# Altium schematic sheet [3]-DCDC-4V2.SchDoc([3]-DCDC-4V2)
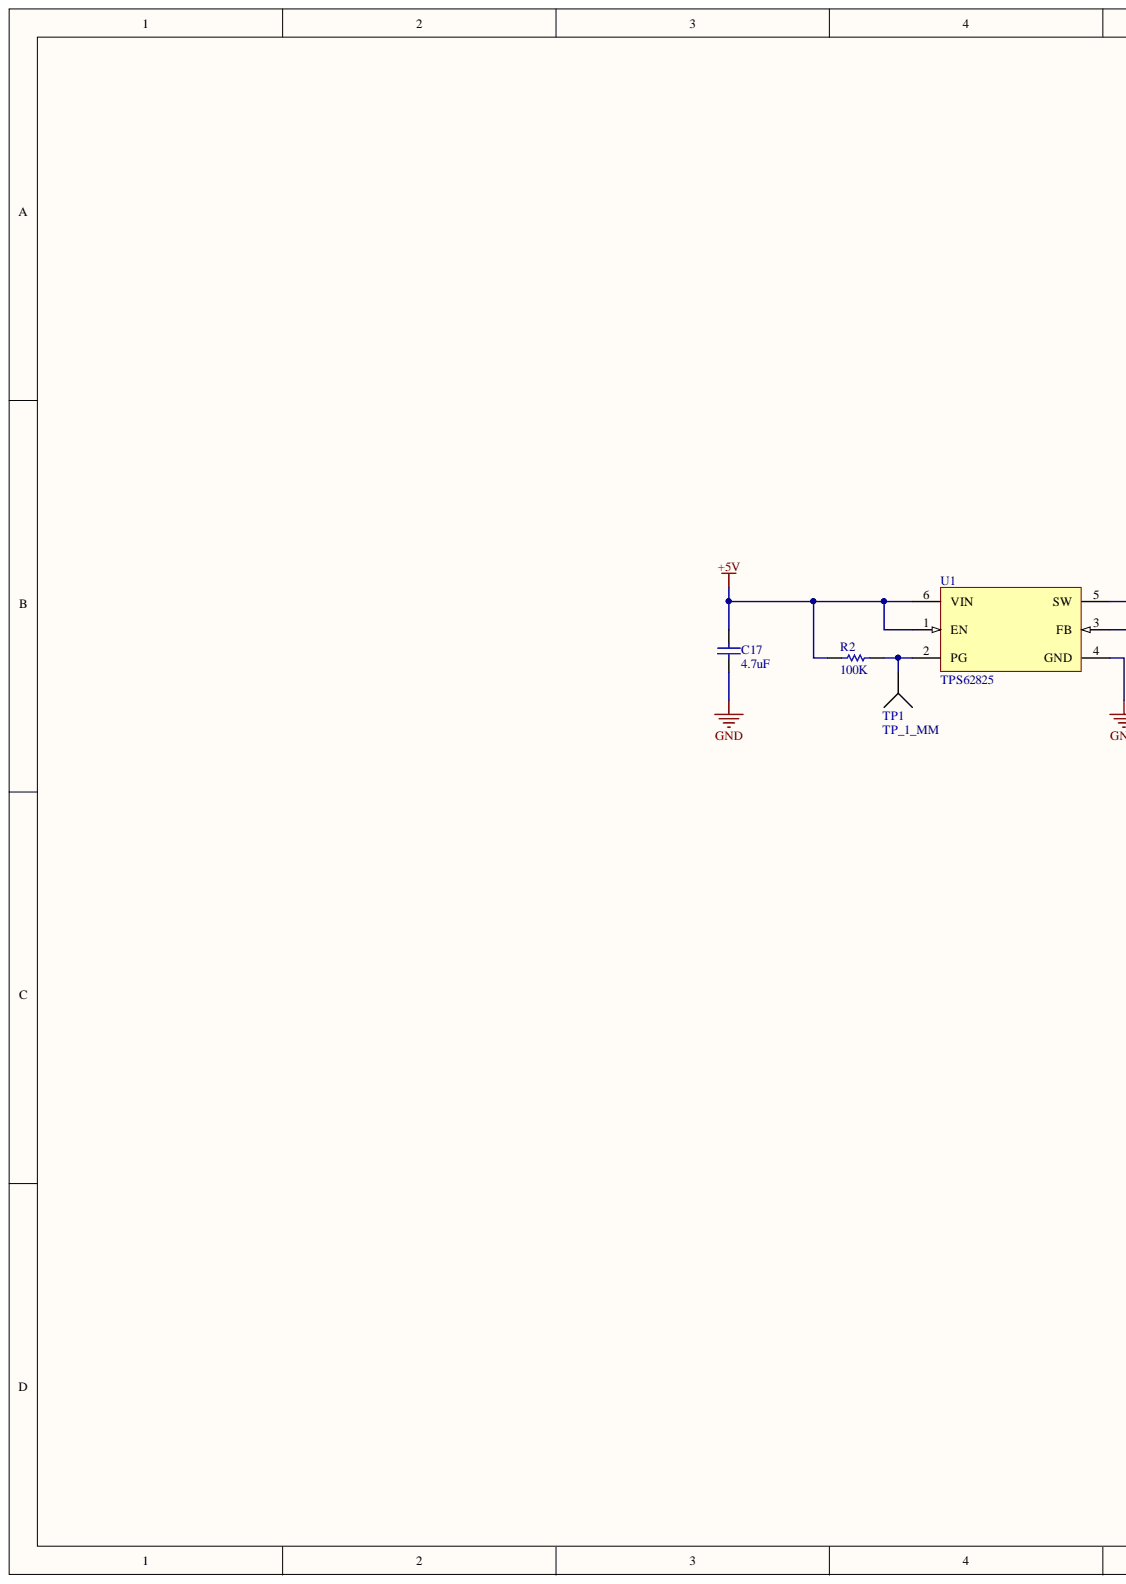
[diagram p0: [3]-DCDC-4V2.SchDoc, left]
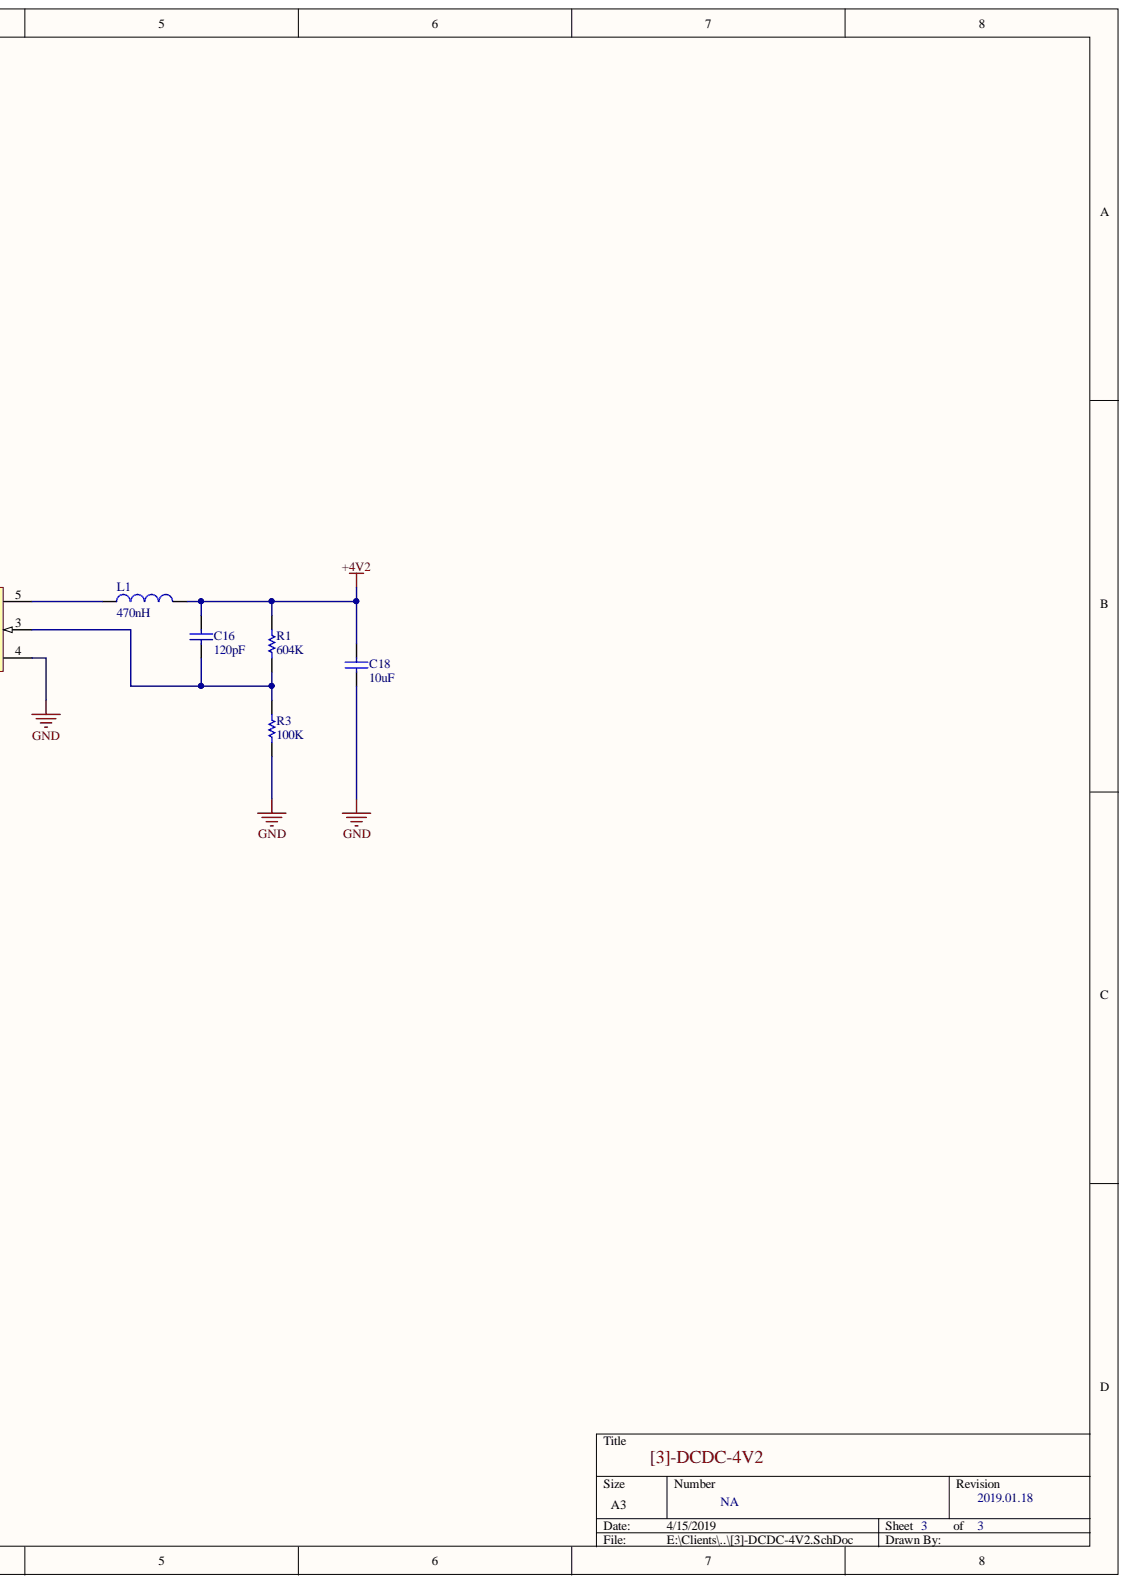
[diagram p1: [3]-DCDC-4V2.SchDoc, right]

## Parts
C16  120pF  [CAPC1005N]
C17  4.7uF  [CAPC2012N]
C18  10uF  [CAPC2012N]
L1  470nH  [IHLP-1212AE-11]
R1  604K  [RESC1005N]
R2  100K  [RESC1005N]
R3  100K  [RESC1005N]
TP1  TP_1_MM  [TP_1_MM]  (no BOM)
U1  TPS62825  [DMQ0006A]

## Nets
+4V2: C16.2 C18.2 L1.2 R1.2
+5V: C17.2 R2.1 U1.1(EN) U1.6(VIN)
GND: C17.1 C18.1 R3.1 U1.4(GND)
NetC16_1: C16.1 R1.1 R3.2 U1.3(FB)
NetL1_1: L1.1 U1.5(SW)
NetR2_2: R2.2 TP1.1 U1.2(PG)

## Library data of the parts
C16 = GRM1555C1H121FA01D: CAP CER 120PF 50V C0G/NP0 0402; Manufacturer 1: Murata; Type: General Purpose MLCC; Tolerance: 1 %; Temperature Coefficient / Code: +/- 30 PPM / C; Temperature Coefficient: 30ppm/°C; Material: Ceramic; Dielectric: C0G (NP0); Description 1: Multilayer Ceramic Capacitors MLCC - SMD/SMT 0402 120pF 50volts 1%; Case Width: 0.5 mm; Case Length: 1 mm; Brand: Murata Electronics; Width: 0.5mm; Voltage Rating DC: 50 V; Voltage Rating (DC): 50V; Voltage Rating: 50 V; Voltage: 50V; Thickness: 0.022inch; Termination Style: SMD/SMT; Termination: SMD/SMT; Supplier Part Number 2: 490-1292-1-ND; Supplier Part Number 1: 490-8182-1-ND; Supplier 2: Digi-Key; Supplier 1: Digi-Key; Stock 2: 100000; Stock 1: 154558; Series: GRM; RoHS: Details; REACH SVHC: No SVHC; Radiation Hardening: No; Product Category: Multilayer Ceramic Capacitors MLCC - SMD/SMT; Product: General Type MLCCs; Pricing 2: 1=0.1, 10=0.065, 100=0.0289, 500=0.02066, 1000=0.01623, 2500=0.01475, 5000=0.01357 (USD); Pricing 1: 1=0.15, 10=0.107, 100=0.0501, 500=0.0351, 1000=0.0291, 2500=0.0271, 5000=0.0248 (USD); Packaging: Reel; Package Quantity: 1; Package / Case: 0402 (1005 metric); Operating Temperature Range: - 55 C to + 125 C; Mount: Surface Mount; Min Operating Temperature: -55°C; Minimum Operating Temperature: - 55 C; Max Operating Temperature: 125°C; Maximum Operating Temperature: + 125 C; Manufacturer Part Number 2: GRM1555C1H121JA01D; Manufacturer Part Number 1: GRM1555C1H121FA01D; Manufacturer 2: Murata; Library Name: bitsnio.SchLib; Length: 1mm; Lead Free: Lead Free; Height: 0.5mm; Factory Pack Quantity: 10000; Dimensions: 0.5 mm W x 1 mm L x 0.5 mm H; Depth: 0.5mm; ComponentLink2URL: https://datasheet.ciiva.com/17451/c02e-17451502.pdf; ComponentLink2Description: https://datasheet.ciiva.com/17451/c02e-17451502.pdf; ComponentLink1URL: https://datasheet.ciiva.com/11617/c02e-11617455.pdf; ComponentLink1Description: https://datasheet.ciiva.com/11617/c02e-11617455.pdf; Component Kind: Standard; Case Height: 0.5 mm; Case Code - mm: 1005; Case Code - in: 0402; Case Code (Metric): 1005; Case Code (Imperial): 0402; Case/Package: 0402; Capacitance: 120 pF
C17 = C2012X5R1E475K125AB: CAP CER 4.7UF 25V X5R 0805; Manufacturer 1: TDK; Tolerance: 10%; Dielectric Material: Ceramic; Width: 1.25mm; Weight: 0.000194oz; Voltage Rating (DC): 25V; Voltage Rating: 25V; Voltage: 25V; Thickness: 0.057inch; Termination: SMD/SMT; Supplier Part Number 1: 445-4116-1-ND; Supplier 1: Digi-Key; Stock 1: 20000; REACH SVHC: No SVHC; Radiation Hardening: No; Pricing 1: 1=0.38, 10=0.259, 100=0.1466, 500=0.1035, 1000=0.09056 (USD); Packaging: Tape and Reel; Package Quantity: 1; Number of Pins: 2; Mount: Surface Mount; Min Operating Temperature: -55°C; Max Operating Temperature: 85°C; Manufacturer Part Number 1: C2012X5R1E475K125AB; Library Name: bitsnio.SchLib; Length: 2mm; Lead Free: Lead Free; Height: 1.25mm; Dielectric: X5R; Depth: 1.25mm; Composition: Ceramic; ComponentLink10URL: https://datasheet.ciiva.com/16449/e412-c-16449599.pdf; ComponentLink10Description: https://datasheet.ciiva.com/16449/e412-c-16449599.pdf; ComponentLink9URL: https://datasheet.ciiva.com/6251/tdkmlcccaprange-6251823.pdf; ComponentLink9Description: https://datasheet.ciiva.com/6251/tdkmlcccaprange-6251823.pdf; ComponentLink8URL: https://datasheet.ciiva.com/28602/1744655-28602173.pdf; ComponentLink8Description: https://datasheet.ciiva.com/28602/1744655-28602173.pdf; ComponentLink7URL: https://datasheet.ciiva.com/6251/tdkmlcccaprange-6251820.pdf; ComponentLink7Description: https://datasheet.ciiva.com/6251/tdkmlcccaprange-6251820.pdf; ComponentLink6URL: https://datasheet.ciiva.com/22676/1571323-22676937.pdf; ComponentLink6Description: https://datasheet.ciiva.com/22676/1571323-22676937.pdf; ComponentLink5URL: https://datasheet.ciiva.com/6251/tdkmlcccaprange-6251817.pdf; ComponentLink5Description: https://datasheet.ciiva.com/6251/tdkmlcccaprange-6251817.pdf; ComponentLink4URL: https://datasheet.ciiva.com/22773/1571323-22773430.pdf; ComponentLink4Description: https://datasheet.ciiva.com/22773/1571323-22773430.pdf; ComponentLink3URL: https://datasheet.ciiva.com/36857/1796654-36857301.pdf; ComponentLink3Description: https://datasheet.ciiva.com/36857/1796654-36857301.pdf; ComponentLink2URL: https://datasheet.ciiva.com/11788/0900766b80c1bb00-11788107.pdf; ComponentLink2Description: https://datasheet.ciiva.com/11788/0900766b80c1bb00-11788107.pdf; ComponentLink1URL: https://datasheet.ciiva.com/24216/tdkmlcccaprange-24216480.pdf; ComponentLink1Description: https://datasheet.ciiva.com/24216/tdkmlcccaprange-24216480.pdf; Component Kind: Standard; Case Code (Metric): 2012; Case Code (Imperial): 0805; Case/Package: 0805; Capacitance: 4.7uF
C18 = C2012X7R1A106K125AC: CAP CER 10UF 10V X7R 0805; Manufacturer 1: TDK; Tolerance: 10%; Dielectric Material: Ceramic; Width: 0.049inch; Weight: 0.000194oz; Voltage Rating (DC): 10V; Voltage Rating: 10V; Voltage: 10V; Thickness: 0.057inch; Termination: SMD/SMT; Supplier Part Number 1: 445-6857-1-ND; Supplier 1: Digi-Key; Stock 1: 20000; REACH SVHC: No SVHC; Radiation Hardening: No; Pricing 1: 1=0.52, 10=0.359, 100=0.2156, 500=0.16172, 1000=0.13656 (USD); Packaging: Tape and Reel; Package Quantity: 1; Number of Pins: 2; Mount: Surface Mount; Min Operating Temperature: -55°C; Max Operating Temperature: 125°C; Manufacturer Part Number 1: C2012X7R1A106K125AC; Library Name: bitsnio.SchLib; Length: 2mm; Lead Free: Lead Free; Height: 1.25mm; Dielectric: X7R; Depth: 1.25mm; Contact Plating: Matte,Tin; Composition: Ceramic; Component Kind: Standard; Case Code (Metric): 2012; Case Code (Imperial): 0805; Case/Package: 0805; Capacitance: 10uF
L1 = IHLP1212AEERR47M11: FIXED IND 470NH 6.7A 15 MOHM SMD; Manufacturer 1: Vishay Dale; Tolerance: 20%; Test Frequency: 100kHz; Shielding: Shielded; Self Resonant Frequency: 1MHz; DC Resistance (DCR): 15mR; Core Material: Ferrite; Width: 3mm; Termination: SMD/SMT; Supplier Part Number 1: 541-1330-1-ND; Supplier 1: Digi-Key; Stock 1: 27366; Saturation Current: 6.7A; RMS Current (Irms): 6.7A; REACH SVHC: Unknown; Radiation Hardening: No; Pricing 1: 1=1.41, 10=1.308, 100=0.9459, 500=0.7245, 1000=0.644 (USD); Packaging: Tape and Reel; Package Quantity: 3000; Number of Pins: 2; Mount: Surface Mount; Min Operating Temperature: -55°C; Military Standard: Not; Max Operating Temperature: 125°C; Max DC Current: 6.7A; Manufacturer Part Number 1: IHLP1212AEERR47M11; Library Name: bitsnio.SchLib; Length: 3.6mm; Lead Free: Lead Free; Inductance Tolerance: 20%; Inductance: 470nh; Height - Seated (Max): 0.059inch; Height: 1.2mm; Depth: 3.2mm; Current Rating: 6.7A; Component Kind: Standard; Case/Package: 1212
R1 = RC0402FR-07604KL: RES SMD 604K OHM 1% 1/16W 0402; Manufacturer 1: Yageo; Working Voltage: 50V; Temperature Coefficient: 100ppm/°C; Resistance: 604kR; Power Rating: 62.5mW; Max Power Dissipation: 63mW; Width: 0.02inch; Voltage Rating (DC): 50V; Voltage Rating: 50V; Tolerance: 1%; Termination: SMD/SMT; Supplier Part Number 1: YAG3198CT-ND; Supplier 1: Digi-Key; Stock 1: 119604; Radiation Hardening: No; Pricing 1: 1=0.1, 10=0.022, 100=0.009, 1000=0.00402, 2500=0.00349, 5000=0.00288 (USD); Packaging: Tape and Reel; Number of Terminations: 2; Min Operating Temperature: -55°C; Military Standard: Not; Max Operating Temperature: 155°C; Manufacturer Part Number 1: RC0402FR-07604KL; Library Name: bitsnio.SchLib; Length: 0.039inch; Lead Free: Lead Free; Height: 0.016inch; Features: Moisture Resistant; Depth: 0.5mm; Contact Plating: Lead,Matte,Tin; Composition: Thick Film; Component Kind: Standard; Case Code (Metric): 1005; Case Code (Imperial): 0402; Case/Package: 0402
R2 R3 = RC0402FR-07100KL: RES SMD 100K OHM 1% 1/16W 0402; Manufacturer 1: Yageo; Voltage Rating: 50 V; Temperature Coefficient: 100 PPM / C; Resistance: 100 kOhms; Power Rating: 62.5 mW (1/16 W); Tolerance: 1 %; Termination Style: SMD/SMT; Supplier Part Number 1: 311-100KLRCT-ND; Supplier 1: Digi-Key; Stock 1: 10691398; Series: RC; Product: Thick Film Resistors General Purpose; Pricing 1: 1=0.1, 10=0.014, 100=0.0056, 1000=0.0025, 2500=0.0022, 5000=0.0018 (USD); Packaging: Reel; Operating Temperature Range: - 55 C to + 155 C; Manufacturer Part Number 1: RC0402FR-07100KL; Library Name: bitsnio.SchLib; Description 1: RES SMD 100K OHM 1% 1/16W 0402; ComponentLink8URL: https://datasheet.ciiva.com/22747/1018594-22747509.pdf; ComponentLink8Description: https://datasheet.ciiva.com/22747/1018594-22747509.pdf; ComponentLink7URL: https://datasheet.ciiva.com/2453/pyu-r-int-thick-7-2453363.pdf; ComponentLink7Description: https://datasheet.ciiva.com/2453/pyu-r-int-thick-7-2453363.pdf; ComponentLink6URL: https://datasheet.ciiva.com/2453/pyu-r-int-thick-7-2453362.pdf; ComponentLink6Description: https://datasheet.ciiva.com/2453/pyu-r-int-thick-7-2453362.pdf; ComponentLink5URL: https://datasheet.ciiva.com/15189/getdatasheetpartid-323696-15189334.pdf; ComponentLink5Description: https://datasheet.ciiva.com/15189/getdatasheetpartid-323696-15189334.pdf; ComponentLink4URL: https://datasheet.ciiva.com/2453/pyu-r-int-thick-7-2453361.pdf; ComponentLink4Description: https://datasheet.ciiva.com/2453/pyu-r-int-thick-7-2453361.pdf; ComponentLink3URL: https://datasheet.ciiva.com/12667/pyu-rc0402-51-rohs-l-6-r-12667930.pdf; ComponentLink3Description: https://datasheet.ciiva.com/12667/pyu-rc0402-51-rohs-l-6-r-12667930.pdf; ComponentLink2URL: https://datasheet.ciiva.com/16740/pyu-rc0402-51-rohs-l-6-r-16740567.pdf; ComponentLink2Description: https://datasheet.ciiva.com/16740/pyu-rc0402-51-rohs-l-6-r-16740567.pdf; ComponentLink1URL: https://datasheet.ciiva.com/11819/0900766b80c66297-11819452.pdf; ComponentLink1Description: https://datasheet.ciiva.com/11819/0900766b80c66297-11819452.pdf; Component Kind: Standard; Case Code - mm: 1005; Case Code - in: 0402
U1 = IC_TPS62825DMQR: IC STEP DOWN CONV 1-5V 2A QFN; Manufacturer 1: Texas Instruments; Supplier Part Number 1: 296-49521-1-ND; Supplier 1: Digi-Key; Stock 1: 1427; Pricing 1: 1=1.56, 10=1.392, 100=1.085, 500=0.8963, 1000=0.70761 (USD); Manufacturer Part Number 1: TPS62825DMQR; Library Name: bitsnio.SchLib; Component Kind: Standard
TP1 = TP_1_MM: Library Name: bitsnio.SchLib
